# Altium schematic sheet 780-00055, rev2, Pg1, SCH, NRES Temp Meas, 8-Chan RTD .SchDoc
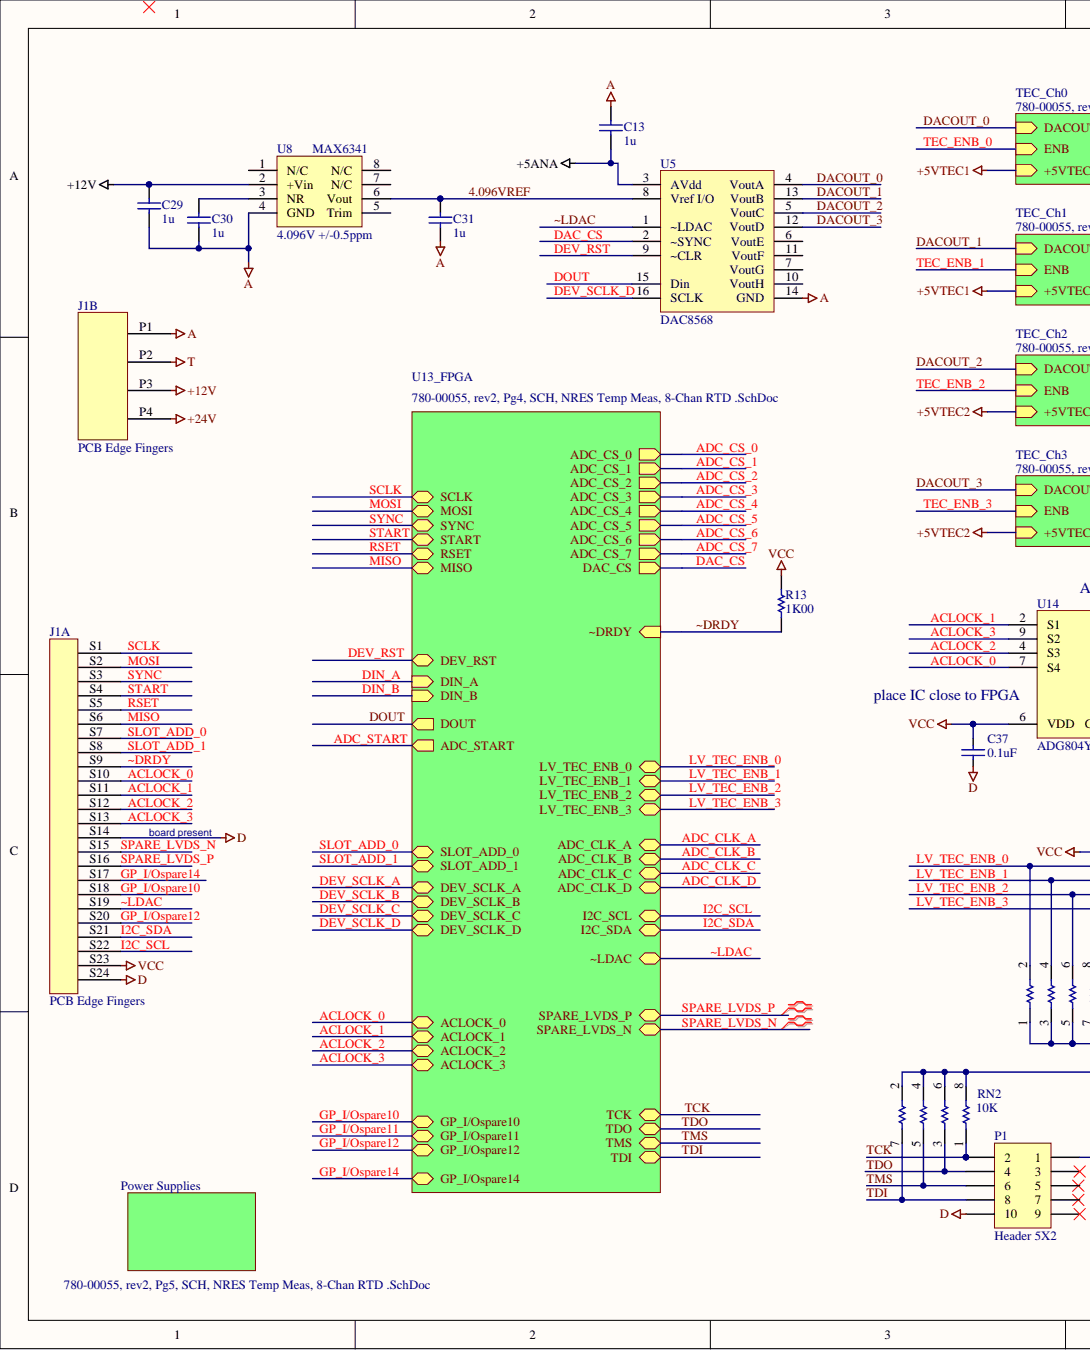
[diagram p0: 780-00055, rev2, Pg1, SCH, NRES Temp Meas, 8-Chan RTD .SchDoc, left]
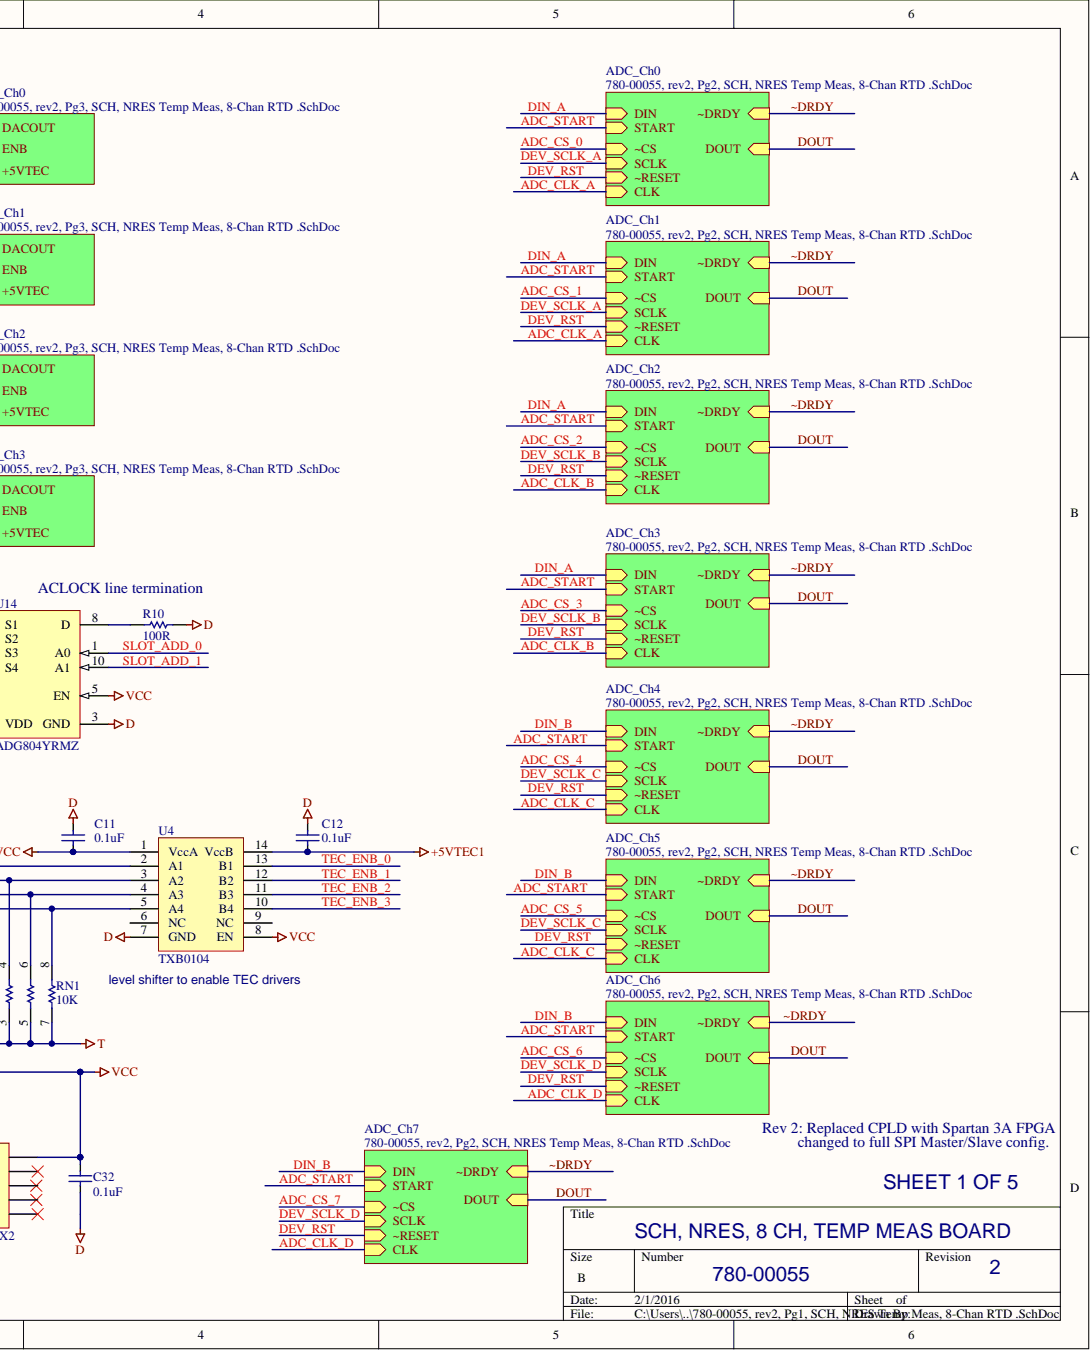
[diagram p1: 780-00055, rev2, Pg1, SCH, NRES Temp Meas, 8-Chan RTD .SchDoc, right]

## Parts
C11  value 0.1uF  [SMD_0805]  (hidden comment: Cap SMD)
C12  value 0.1uF  [SMD_0805]  (hidden comment: Cap SMD)
C13  1u  [SMD_0805]
C29  1u  [SMD_0805]
C30  1u  [SMD_0805]
C31  1u  [SMD_0805]
C32  value 0.1uF  [SMD_0805]  (hidden comment: Cap SMD)
C37  value 0.1uF  [SMD_0805]  (hidden comment: Cap SMD)
J1  PCB Edge Fingers  [PCB EDGE, MOLEX 46114]  (parts A,B)
P1  Header 5X2  [HDR 2x5 RT, SAMTEC TSW]
R10  value 100R  [SMD_0805]  (hidden comment: Res 0805)
R13  value 1K00  [SMD_0805]  (hidden comment: Res 0805)
RN1  value 10K  [RES ARRAY 0603 x 4]  (hidden comment: RES ARRAY  x4 10K)
RN2  value 10K  [RES ARRAY 0603 x 4]  (hidden comment: RES ARRAY  x4)
U4  TXB0104  [TSSOP14]
U5  DAC8568  [TSSOP16]
U8  4.096V +/-0.5ppm  value MAX6341  [SO-8_M]
U14  ADG804YRMZ  [ADI-RM-10_L]

## Nets
+5ANA: C13.2 U5.3(AVdd)
+5VTEC1: C12.1 U4.14(VccB)
+12V: C29.1 J1.P3 U8.2(+Vin)
+24V: J1.P4
A: C13.1 C29.2 C30.2 C31.2 J1.P1 U5.14(GND) U8.4(GND)
D: C11.2 C12.2 C32.1 C37.1 J1.S14 J1.S24 P1.10 R10.2 U4.7(GND) U14.3(GND)
T: J1.P2 RN1.1 RN1.3 RN1.5 RN1.7
VCC: C11.1 C32.2 C37.2 J1.S23 P1.1 R13.2 RN2.2 RN2.4 RN2.6 RN2.8 U4.1(VccA) U4.8(EN) U14.5(EN) U14.6(VDD)
4.096VREF: C31.1 U5.8(Vref_I/O) U8.6(Vout)
ACLOCK_0: J1.S10 U14.7(S4)
ACLOCK_1: J1.S11 U14.2(S1)
ACLOCK_2: J1.S12 U14.4(S3)
ACLOCK_3: J1.S13 U14.9(S2)
DAC_CS: U5.2(~SYNC)
DACOUT_0: U5.4(VoutA)
DACOUT_1: U5.13(VoutB)
DACOUT_2: U5.5(VoutC)
DACOUT_3: U5.12(VoutD)
DEV_RST: U5.9(~CLR)
DEV_SCLK_D: U5.16(SCLK)
DOUT: U5.15(Din)
GP_I/OSPARE10: J1.S18
GP_I/OSPARE12: J1.S20
GP_I/OSPARE14: J1.S17
I2C_SCL: J1.S22
I2C_SDA: J1.S21
LV_TEC_ENB_0: RN1.2 U4.2(A1)
LV_TEC_ENB_1: RN1.4 U4.3(A2)
LV_TEC_ENB_2: RN1.6 U4.4(A3)
LV_TEC_ENB_3: RN1.8 U4.5(A4)
MISO: J1.S6
MOSI: J1.S2
RSET: J1.S5
SCLK: J1.S1
SLOT_ADD_0: J1.S7 U14.1(A0)
SLOT_ADD_1: J1.S8 U14.10(A1)
SPARE_LVDS_N: J1.S15
SPARE_LVDS_P: J1.S16
START: J1.S4
SYNC: J1.S3
TCK: P1.2 RN2.1
TDI: P1.8 RN2.7
TDO: P1.4 RN2.3
TEC_ENB_0: U4.13(B1)
TEC_ENB_1: U4.12(B2)
TEC_ENB_2: U4.11(B3)
TEC_ENB_3: U4.10(B4)
TMS: P1.6 RN2.5
~DRDY: J1.S9 R13.1
~LDAC: J1.S19 U5.1(~LDAC)
NetC30_1: C30.1 U8.3(NR)
NetP1_3: P1.3
NetP1_5: P1.5
NetP1_7: P1.7
NetP1_9: P1.9
NetR10_1: R10.1 U14.8(D)
NetU4_6: U4.6(NC)
NetU4_9: U4.9(NC)
NetU5_6: U5.6(VoutE)
NetU5_7: U5.7(VoutG)
NetU5_10: U5.10(VoutH)
NetU5_11: U5.11(VoutF)
NetU8_1: U8.1(N/C)
NetU8_5: U8.5(Trim)
NetU8_7: U8.7(N/C)
NetU8_8: U8.8(N/C)
+5VTEC2:
ADC_CLK_A:
ADC_CLK_B:
ADC_CLK_C:
ADC_CLK_D:
ADC_CS_0:
ADC_CS_1:
ADC_CS_2:
ADC_CS_3:
ADC_CS_4:
ADC_CS_5:
ADC_CS_6:
ADC_CS_7:
ADC_START:
DEV_SCLK_A:
DEV_SCLK_B:
DEV_SCLK_C:
DIN_A:
DIN_B:
GP_I/OSPARE11:

## Library data of the parts
C11 C12 C37 = Cap SMD: Capacitor; LCO p/n: 120-00002; Component Kind: Standard; Library Name: Richs Schematic Components.SchLib
C13 C29-C31 = Cap: Capacitor; Manufacturer: Taiyo Yuden; Manuf p/n: UMK212F105ZG-T; LCO p/n: 120-00027; Vendor: DIGIKEY; Vendor Mult.: 10; Vendor p/n: 587-1308-1-ND; Component Kind: Standard; COST: 0.088; Library Name: Miscellaneous Devices.IntLib; Signal Integrity: Cap; Simulation: CAP; Value: 1u
C32 = Cap SMD: Capacitor; Manufacturer: AVX; Manuf p/n: 08055C104KAT2A; LCO P/N: 120-00002; Vendor: DigiKey; Vendor Mult.: 10; Vendor p/n: 478-1395-1-ND; Component Kind: Standard; COST: 0.088; Library Name: Richs Schematic Components.SchLib
J1 = Conn, PCB Card Edge / Molex 46114, 24 sig / 4 pwr: PCB Edge Conn to fit Molex 46114 backplane connector; Component Kind: Standard; Library Name: Richs Schematic Components.SchLib
P1 = Header 5X2: Header, 5-Pin, Dual row; Manufacturer: FCI; LCO P/N: 125-00396; Vendor: DigiKey; Vendor Mult.: 1; Component Kind: Standard; COST: 0.81; Library Name: Miscellaneous Connectors.IntLib; Signal Integrity: Connector; Value: Header 5X2
R10 = Res SMD: Resistor 0805; Manufacturer: Vishay/Dale; Manuf p/n: CRCW0805100RFKEA; LCO p/n: 181-01000; Vendor: DIGIKEY; Vendor Mult.: 10; Vendor p/n: 541-100RCCT-ND; Component Kind: Standard; COST: 0.09; Library Name: Richs Schematic Components.SchLib
R13 = Res SMD: Resistor 0805; Manufacturer: Vishay/Dale; Manuf p/n: CRCW08051K00FKEA; LCO p/n: 181-01001; Vendor: DIGIKEY; Vendor Mult.: 10; Vendor p/n: 541-1K00CCT-ND; Component Kind: Standard; COST: 0.09; Library Name: Richs Schematic Components.SchLib
RN1 = RES ARRAY  x4 10K: Resistor Array 4 Isolated; Manufacturer: PANASONIC - ECG; Manuf p/n: EXB-38V103JV; LCO p/n: 180-00043; Vendor: DIGIKEY; Vendor Mult.: 10; Vendor p/n: Y9103CT-ND; Component Kind: Standard; COST: 0.03; Library Name: Richs Schematic Components.SchLib
RN2 = RES ARRAY  x4: Resistor Array 4 Isolated; Manufacturer: Panasonic ECG; Manuf p/n: EXB-38V103JV; LCO P/N: 180-00043; Vendor: DigiKey; Vendor Mult.: 10; Vendor p/n: Y9103CT-ND; Component Kind: Standard; COST: 0.031; Library Name: Richs Schematic Components.SchLib
U4 = TXB0104: 4-Chan Logic Level Shifter Bi-directional; Manufacturer: TI; Manuf p/n: TXB0104PWR; LCO p/n: 150-00108; Vendor: DK; Vendor p/n: 296-21929-1-ND; Component Kind: Standard; VALUE: TXB0104; Library Name: Richs Schematic Components.SchLib
U5 = DAC8568: 8 Chan, 16-bit DAC, SPI Interface; Manufacturer: TI; Manuf p/n: DAC8568IAPW; LCO p/n: 150-00107; Vendor: DK; Vendor p/n: 296-24444-5-ND; Component Kind: Standard; VALUE: DAC8568; Library Name: Richs Schematic Components.SchLib
U8 = MAX6341: VREF 4.096V 0.5ppm; Manufacturer: MAXIM; Manuf p/n: MAX6341CSA+; LCO p/n: 150-00052; Vendor: DIGIKEY; Vendor Mult.: 1; Vendor p/n: MAX6341CSA+-ND; Component Kind: Standard; COST: 17.76; Library Name: Richs Schematic Components.SchLib
U14 = CMP-0798-00106-1: 1.65 to 3.6 V, CMOS, 0.5 Ohm, 4-Channel Multiplexer, -40 to 125 degC, 10-pin SOP (RM-10), Tube; Manufacturer: Analog Devices; Bandwidth (Hz): 33M; LCO p/n: 150-00116; Max Analog Signal Range: 0 to Vdd; Ron (ohms): 500; Supply V Guar Performance: Single_(+2.5V), Single_(+3.3V), Single_(+3V); t transition (s): 24n; Code_JEDEC: MO-187-BA; Component Kind: Standard; ComponentLink1Description: Manufacturer URL; ComponentLink1URL: http://www.analog.com/en/index.html; ComponentLink2Description: Datasheet; ComponentLink2URL: http://www.analog.com/static/imported-files/data_sheets/ADG804.pdf; ComponentLink3Description: Package Specification; ComponentLink3URL: http://www.analog.com/static/imported-files/packages/PKG_PDF/MSOP%28RM%29/RM_10.pdf; DatasheetVersion: Rev. A; Library Name: Altium Content Vault; Mounting Technology: Surface Mount; PackageDescription: 10-Pin Mini Small Outline Package, Body 3 x 3 mm, Pitch 0.5 mm; PackageReference: RM-10; PackageVersion: Aug-05; Packing: Tube; PartNumber: ADG804YRMZ
